# Altium schematic sheet power_stage.SchDoc(power_stage)
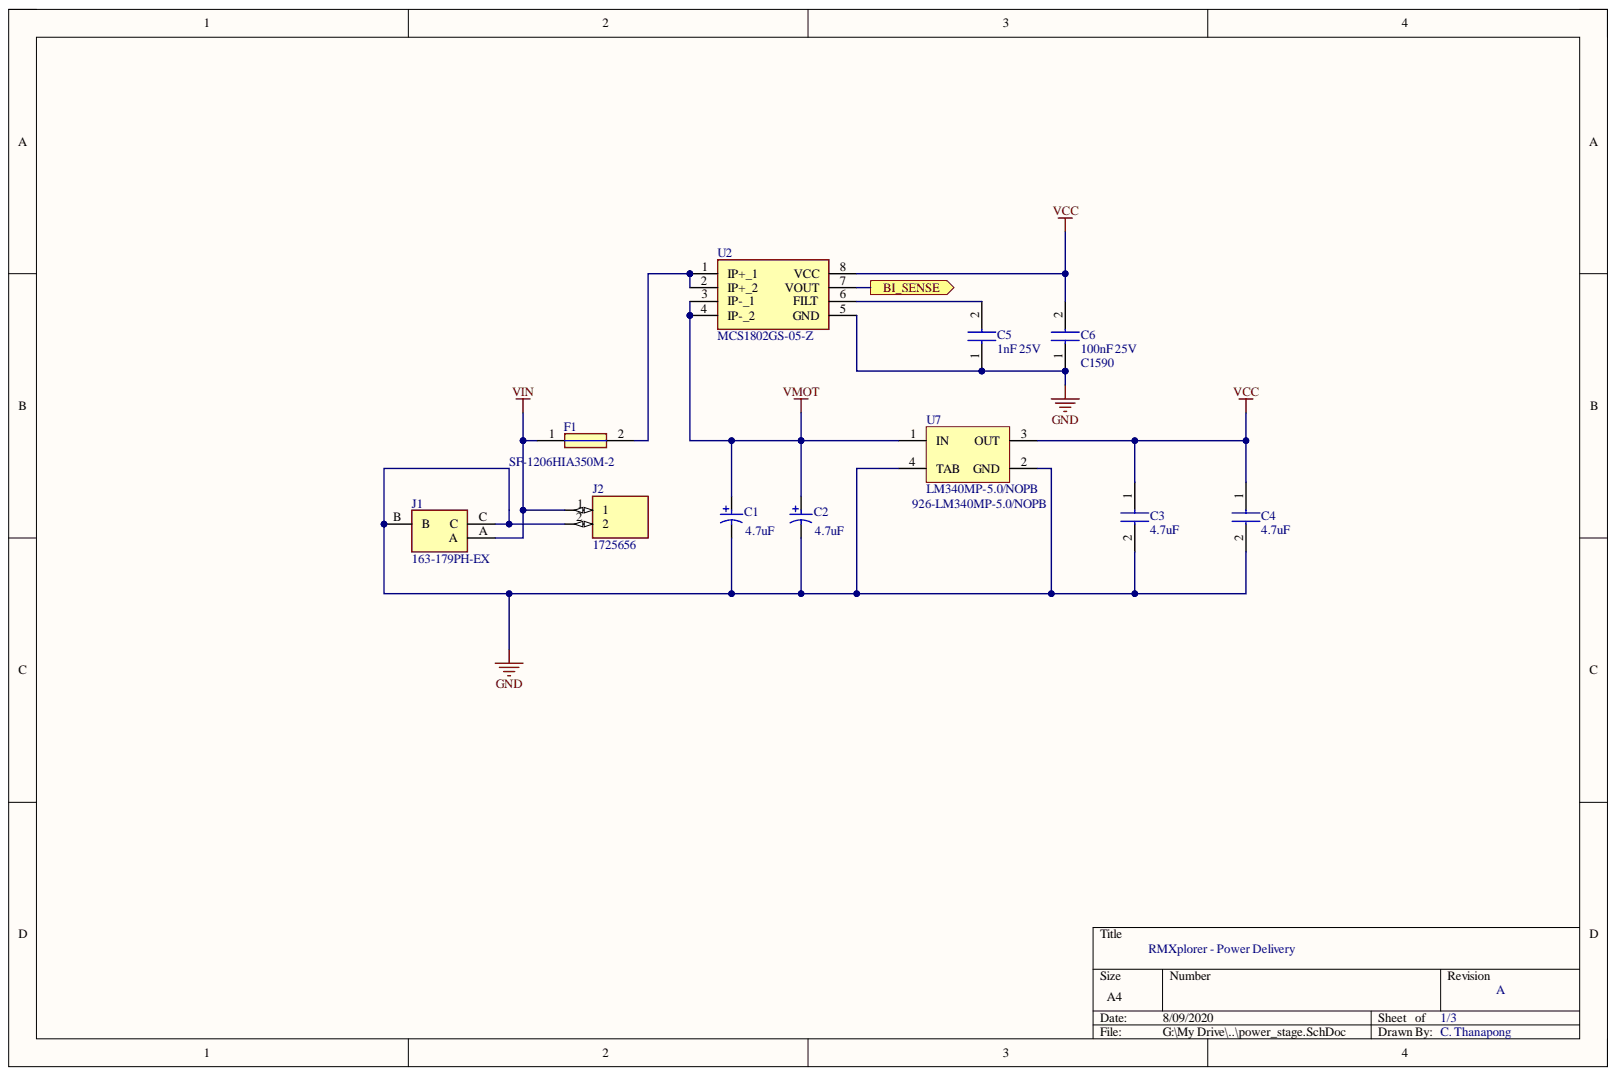
[diagram p0: power_stage.SchDoc]

## Parts
C1  4.7uF  [NIC-CAPA2-5X5.8_V]
C2  4.7uF  [NIC-CAPA2-5X5.8_V]
C3  4.7uF  [CAPC2012X145N]
C4  4.7uF  [CAPC2012X145N]
C5  1nF 25V  [CAPC1608X90N]
C6  100nF 25V  Supplier Part Number 1: C1590  [CAPC1608X90N]
F1  SF-1206HIA350M-2  [FUSC3216X117N]
J1  163-179PH-EX  [163179PHEX]
J2  1725656  [1725656]
U2  MCS1802GS-05-Z  [SOIC127P600X175-8N]
U7  LM340MP-5.0/NOPB  Supplier Part Number 1: 926-LM340MP-5.0/NOPB  [DCY0004A_L]

## Ports
BI_SENSE

## Nets
GND: C1.2 C2.2 C3.2 C4.2 C5.1 C6.1 J1.B J1.C J2.2 U2.5(GND) U7.2(GND) U7.4(TAB)
VCC: C3.1 C4.1 C6.2 U2.8(VCC) U7.3(OUT)
VIN: F1.1 J1.A J2.1
VMOT: C1.1 C2.1 U2.3(IP-_1) U2.4(IP-_2) U7.1(IN)
BI_SENSE: U2.7(VOUT) ; port BI_SENSE
NetC5_2: C5.2 U2.6(FILT)
NetF1_2: F1.2 U2.1(IP+_1) U2.2(IP+_2)

## Library data of the parts
C1 C2 = CMP-0687-00025-1: Aluminum Electrolytic Capacitor, UD Series, Low Impedance, 4.7 uF, 50 V, + / - 20%, -55 to 105 degC, Chip Type, 5 x 5.8 mm D x L, Pb-Free, Reel; Manufacturer: Nichicon; PartNumber: UUD1H4R7MCL1GS; Endurance Time: 2000 h; Impedance: 1.52 Ohm; Packing: Dia382mm Reel; Rated Ripple Current (at 100kHz): 85 mA; Rated Voltage: 50 V; Tolerance Rated Capacitance: -20 to 20%; Component Kind: Standard; ComponentLink1Description: Manufacturer URL; ComponentLink1URL: http://www.nichicon.co.jp/; ComponentLink2Description: Datasheet; ComponentLink2URL: http://products.nichicon.co.jp/en/pdf/XJA043/e-ud.pdf; ComponentLink3Description: Package Specification; ComponentLink3URL: http://products.nichicon.co.jp/en/pdf/XJA043/e-ud.pdf; DatasheetVersion: 08/2012; Diameter: 5 mm; Length: 5.8 mm; Library Name: Altium Content Vault; Mounting Technology: Surface Mount; PackageDescription: 2-Pin Surface Mount Device, Diameter 5 mm, Height 5.8 mm, Pitch 4.4 mm; PackageReference: CAPAE-D5H5.8; Rated Capacitance: 4.7 uF; RoHS: TRUE
C3 C4 = GRM21BR71C475KE51L: Capacitor; Manufacturer_Name: Murata Electronics; Arrow Part Number: GRM21BR71C475KE51L; Arrow Price/Stock: https://www.arrow.com/en/products/grm21br71c475ke51l/murata-manufacturing; Component Kind: Standard; Datasheet Link: http://www.murata.com/~/media/webrenewal/support/library/catalog/products/capacitor/mlcc/c02e.pdf; Height: 1.45mm; Manufacturer_Part_Number: GRM21BR71C475KE51L; Mouser Part Number: 81-GRM21BR71C475KE1L; Mouser Price/Stock: https://www.mouser.co.uk/ProductDetail/Murata-Electronics/GRM21BR71C475KE51L?qs=eeBpzGFlv%252B%252BCR4pji%2FtFiA%3D%3D; Library Name: Schlib_rmx.SchLib
C5 C6 = CL10B104KA8NNNC: Capacitor; Manufacturer_Name: Samsung Electro-Mechanics; Arrow Part Number: CL10B104KA8NNNC; Arrow Price/Stock: https://www.arrow.com/en/products/cl10b104ka8nnnc/samsung-electro-mechanics; Component Kind: Standard; Datasheet Link: http://media.digikey.com/pdf/Data%20Sheets/Samsung%20PDFs/CL_Series_MLCC_ds.pdf; Height: 0.9mm; Manufacturer_Part_Number: CL10B104KA8NNNC; Supplier 1: LCSC
F1 = SF-1206HIA350M-2: Fuse; Manufacturer_Name: Bourns; Arrow Part Number: SF-1206HIA350M-2; Component Kind: Standard; Datasheet Link: https://www.mouser.com/catalog/specsheets/Bourns_SF-1206HIA-M_datasheet.pdf; Height: 1.17mm; Manufacturer_Part_Number: SF-1206HIA350M-2; Mouser Part Number: 652-SF-1206HIA350M-2; Mouser Price/Stock: https://www.mouser.co.uk/ProductDetail/Bourns/SF-1206HIA350M-2?qs=GBLSl2AkiruT89goybuDXA%3D%3D; Library Name: Schlib_rmx.SchLib
J1 = 163-179PH-EX: Connector; Manufacturer_Name: Kobiconn; Arrow Part Number: 163-179PH-EX; Component Kind: Standard; Datasheet Link: https://componentsearchengine.com/Datasheets/1/163-179PH-EX.pdf; Height: 11mm; Manufacturer_Part_Number: 163-179PH-EX; Mouser Part Number: 163-179PH-EX; Mouser Price/Stock: https://www.mouser.co.uk/ProductDetail/Kobiconn/163-179PH-EX?qs=Xb8IjHhkxj5l2UOaIqcGCw%3D%3D; Library Name: Schlib_rmx.SchLib
J2 = 1725656: Connector; Manufacturer_Name: Phoenix Contact; Arrow Part Number: 1725656; Arrow Price/Stock: https://www.arrow.com/en/products/1725656/phoenix-contact; Component Kind: Standard; Datasheet Link: https://www.phoenixcontact.com/online/portal/gb?uri=pxc-oc-itemdetail:pid=1725656&library=gben&tab=1; Height: 8mm; Manufacturer_Part_Number: 1725656; Mouser Part Number: 651-1725656; Mouser Price/Stock: https://www.mouser.co.uk/ProductDetail/Phoenix-Contact/1725656?qs=Ul7CXFMnlWWQeccayYbRmw%3D%3D
U2 = MCS1802GS-05-Z: Integrated Circuit; Manufacturer_Name: Monolithic Power Systems (MPS); Arrow Part Number: MCS1802GS-05-Z; Component Kind: Standard; Datasheet Link: https://www.monolithicpower.com/en/documentview/productdocument/index/version/2/document_type/Datasheet/lang/en/sku/MCS1802/document_id/5066/; Height: 1.75mm; Manufacturer_Part_Number: MCS1802GS-05-Z
U7 = CMP-0062-01784-3: Series 3-Terminal Positive Regulators, 4-pin SOT-223, Pb-Free; Manufacturer: Texas Instruments; CompType: LDO IC; PackageTopMarking: XYTT*N00A; PowerWise: N; Code_IPC: SOT223-4; Code_JEDEC: TO-261-AA; Component Kind: Standard; ComponentLink1Description: Datasheet URL; ComponentLink1URL: http://www.ti.com/general/docs/lit/getliterature.tsp?genericPartNumber=LM340-N&fileType=pdf; Library Name: Altium Content Vault; PackageDescription: Molded SOT-223, 6.50 x 3.56 x 1.6mm Body, 4 Lead, 2.3mm Pitch; PackageReference: DCY0004A; PinCount: 4; RoHS: Y; Supplier 1: Mouser
